# Altium schematic sheet PowerSupply.SchDoc
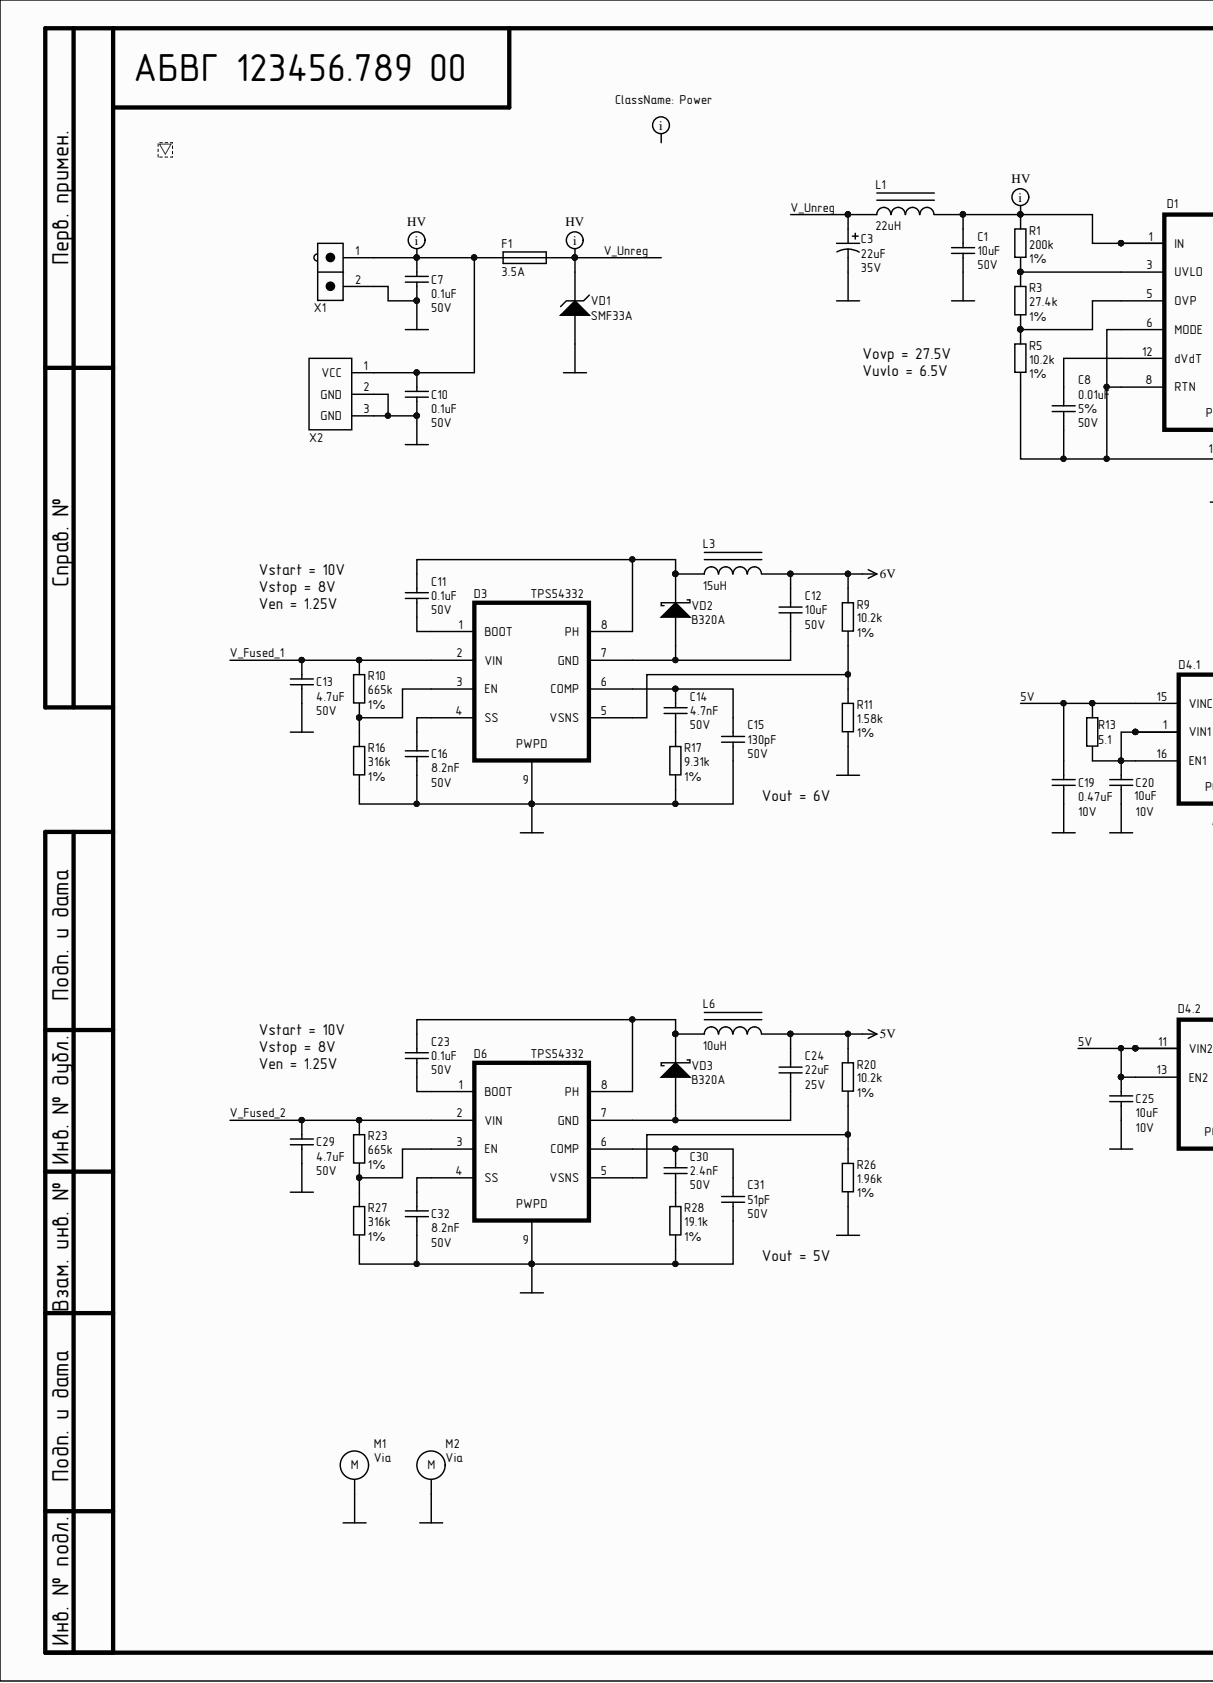
[diagram p0: PowerSupply.SchDoc, left]
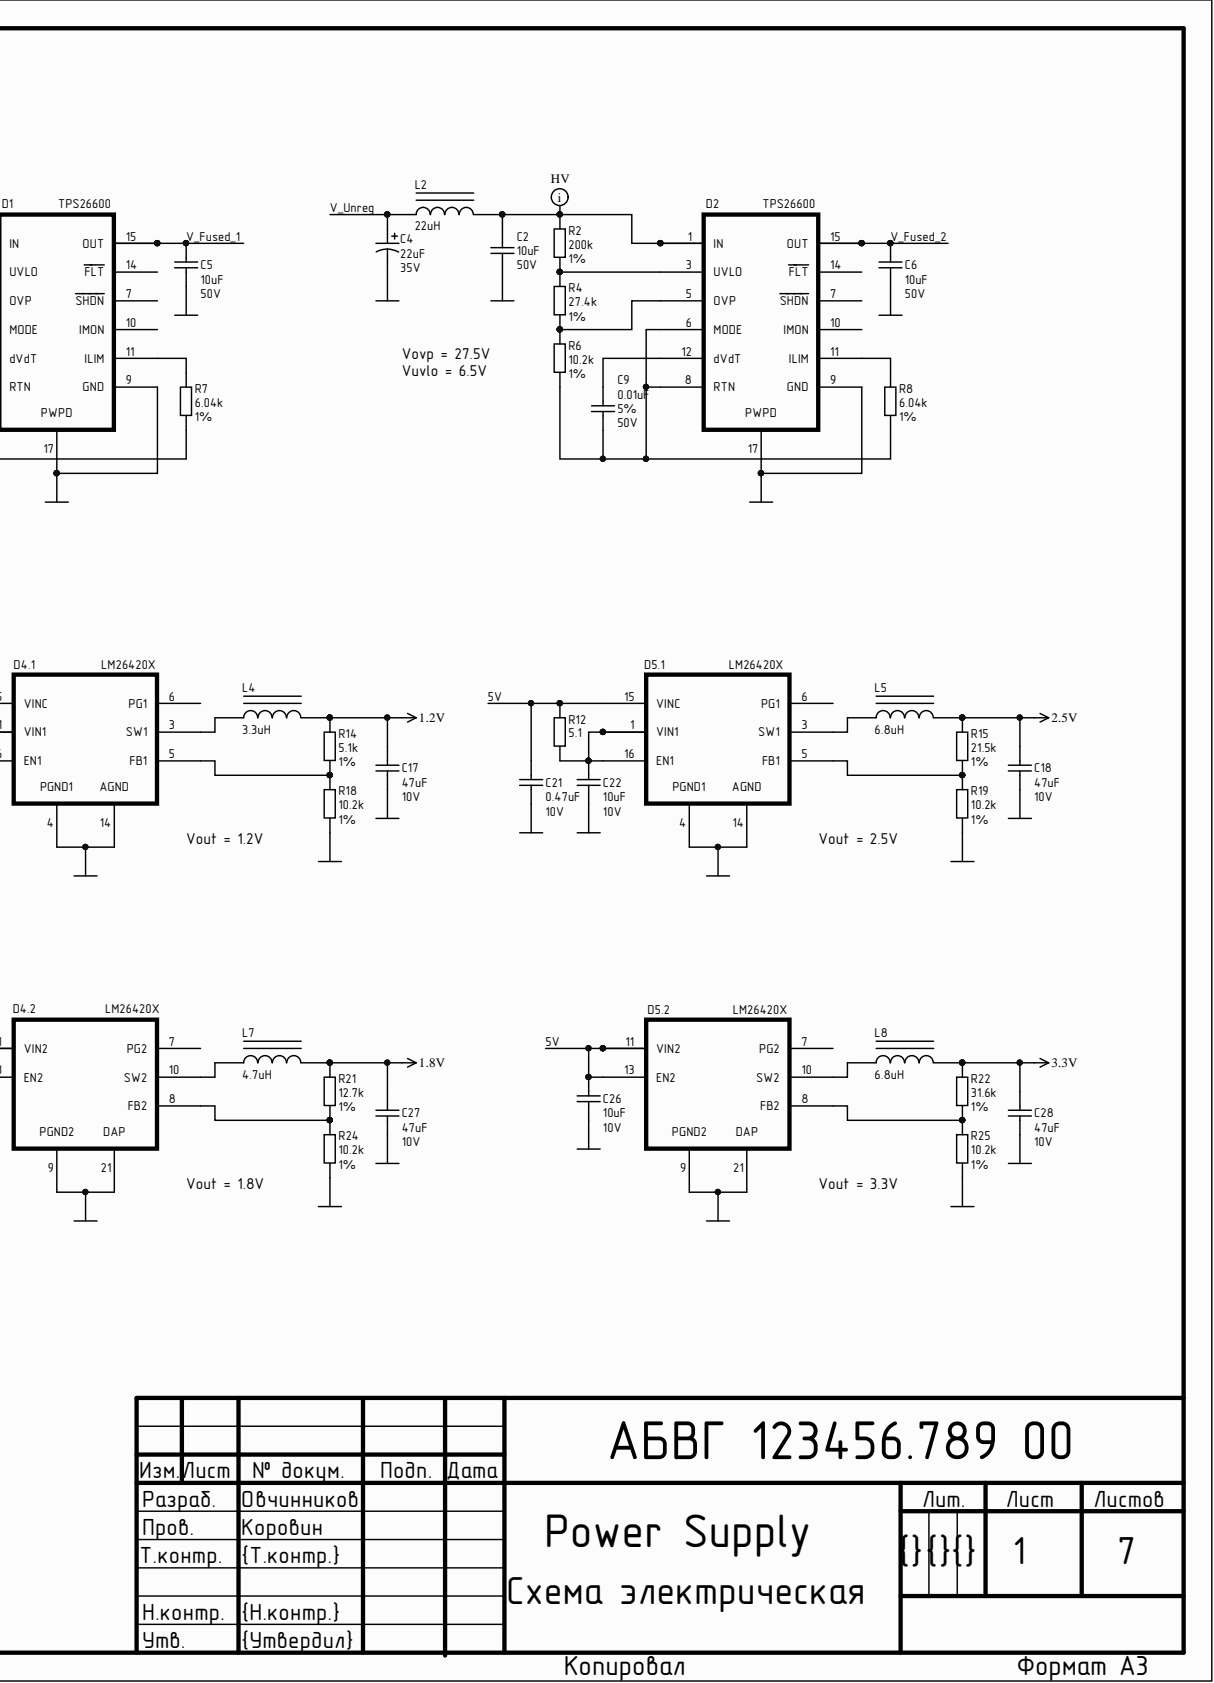
[diagram p1: PowerSupply.SchDoc, right]

## Parts
C1  value 10uF  Voltage: 50V  [C1210]  (hidden comment: CAP uF)
C2  value 10uF  Voltage: 50V  [C1210]  (hidden comment: CAP uF)
C3  value 22uF  Voltage: 35V  [Tantalum_D]  (hidden comment: Cap Polarity)
C4  value 22uF  Voltage: 35V  [Tantalum_D]  (hidden comment: Cap Polarity)
C5  value 10uF  Voltage: 50V  [C1210]  (hidden comment: CAP uF)
C6  value 10uF  Voltage: 50V  [C1210]  (hidden comment: CAP uF)
C7  value 0.1uF  Voltage: 50V  [C0402]  (hidden comment: CAP)
C8  value 0.01uF  Tolerance: 5%  Voltage: 50V  [C0402]  (hidden comment: CAP)
C9  value 0.01uF  Tolerance: 5%  Voltage: 50V  [C0402]  (hidden comment: CAP)
C10  value 0.1uF  Voltage: 50V  [C0402]  (hidden comment: CAP)
C11  value 0.1uF  Voltage: 50V  [C0402]  (hidden comment: CAP)
C12  value 10uF  Voltage: 50V  [C1210]  (hidden comment: CAP uF)
C13  value 4.7uF  Voltage: 50V  [C0805]  (hidden comment: CAP uF)
C14  value 4.7nF  Voltage: 50V  [C0603]  (hidden comment: CAP)
C15  value 130pF  Voltage: 50V  [C0402]  (hidden comment: CAP)
C16  value 8.2nF  Voltage: 50V  [C0402]  (hidden comment: CAP)
C17  value 47uF  Voltage: 10V  [C1210]  (hidden comment: CAP uF)
C18  value 47uF  Voltage: 10V  [C1210]  (hidden comment: CAP uF)
C19  value 0.47uF  Voltage: 10V  [C0603]  (hidden comment: CAP)
C20  value 10uF  Voltage: 10V  [C0805]  (hidden comment: CAP uF)
C21  value 0.47uF  Voltage: 10V  [C0603]  (hidden comment: CAP)
C22  value 10uF  Voltage: 10V  [C0805]  (hidden comment: CAP uF)
C23  value 0.1uF  Voltage: 50V  [C0402]  (hidden comment: CAP)
C24  value 22uF  Voltage: 25V  [C1210]  (hidden comment: CAP uF)
C25  value 10uF  Voltage: 10V  [C0805]  (hidden comment: CAP uF)
C26  value 10uF  Voltage: 10V  [C0805]  (hidden comment: CAP uF)
C27  value 47uF  Voltage: 10V  [C1210]  (hidden comment: CAP uF)
C28  value 47uF  Voltage: 10V  [C1210]  (hidden comment: CAP uF)
C29  value 4.7uF  Voltage: 50V  [C0805]  (hidden comment: CAP uF)
C30  value 2.4nF  Voltage: 50V  [C0603]  (hidden comment: CAP)
C31  value 51pF  Voltage: 50V  [C0402]  (hidden comment: CAP)
C32  value 8.2nF  Voltage: 50V  [C0402]  (hidden comment: CAP)
D1  TPS26600  [R-PDSO-G16]
D2  TPS26600  [R-PDSO-G16]
D3  TPS54332  [R-PDSO-G8]
D4  LM26420X  [HTSSOP-20]  (parts .1,.2)
D5  LM26420X  [HTSSOP-20]  (parts .1,.2)
D6  TPS54332  [R-PDSO-G8]
F1  Current: 3.5A  [Fuse]  (hidden comment: FUSE)
L1  value 22uH  [XAL6060-223]  (hidden comment: Inductor PWR)
L2  value 22uH  [XAL6060-223]  (hidden comment: Inductor PWR)
L3  value 15uH  [XAL5050]  (hidden comment: Inductor PWR)
L4  value 3.3uH  [XAL4030]  (hidden comment: Inductor PWR)
L5  value 6.8uH  [XAL4030]  (hidden comment: Inductor PWR)
L6  value 10uH  [XAL5050]  (hidden comment: Inductor PWR)
L7  value 4.7uH  [XAL4030]  (hidden comment: Inductor PWR)
L8  value 6.8uH  [XAL4030]  (hidden comment: Inductor PWR)
M1  Via  [Mechanical_Via]
M2  Via  [Mechanical_Via]
R1  value 200k  Tolerance: 1%  [R0402]  (hidden comment: RES)
R2  value 200k  Tolerance: 1%  [R0402]  (hidden comment: RES)
R3  value 27.4k  Tolerance: 1%  [R0402]  (hidden comment: RES)
R4  value 27.4k  Tolerance: 1%  [R0402]  (hidden comment: RES)
R5  value 10.2k  Tolerance: 1%  [R0402]  (hidden comment: RES)
R6  value 10.2k  Tolerance: 1%  [R0402]  (hidden comment: RES)
R7  value 6.04k  Tolerance: 1%  [R0402]  (hidden comment: RES)
R8  value 6.04k  Tolerance: 1%  [R0402]  (hidden comment: RES)
R9  value 10.2k  Tolerance: 1%  [R0402]  (hidden comment: RES)
R10  value 665k  Tolerance: 1%  [R0402]  (hidden comment: RES)
R11  value 1.58k  Tolerance: 1%  [R0402]  (hidden comment: RES)
R12  value 5.1  [R0402]  (hidden comment: RES)
R13  value 5.1  [R0402]  (hidden comment: RES)
R14  value 5.1k  Tolerance: 1%  [R0402]  (hidden comment: RES)
R15  value 21.5k  Tolerance: 1%  [R0402]  (hidden comment: RES)
R16  value 316k  Tolerance: 1%  [R0402]  (hidden comment: RES)
R17  value 9.31k  Tolerance: 1%  [R0402]  (hidden comment: RES)
R18  value 10.2k  Tolerance: 1%  [R0402]  (hidden comment: RES)
R19  value 10.2k  Tolerance: 1%  [R0402]  (hidden comment: RES)
R20  value 10.2k  Tolerance: 1%  [R0402]  (hidden comment: RES)
R21  value 12.7k  Tolerance: 1%  [R0402]  (hidden comment: RES)
R22  value 31.6k  Tolerance: 1%  [R0402]  (hidden comment: RES)
R23  value 665k  Tolerance: 1%  [R0402]  (hidden comment: RES)
R24  value 10.2k  Tolerance: 1%  [R0402]  (hidden comment: RES)
R25  value 10.2k  Tolerance: 1%  [R0402]  (hidden comment: RES)
R26  value 1.96k  Tolerance: 1%  [R0402]  (hidden comment: RES)
R27  value 316k  Tolerance: 1%  [R0402]  (hidden comment: RES)
R28  value 19.1k  Tolerance: 1%  [R0402]  (hidden comment: RES)
VD1  SMF33A  [SOD-123F]
VD2  B320A  [Diode_SMA]
VD3  B320A  [Diode_SMA]
X1  miniFit  [2x2 PWR_HDR]  (value not drawn)
X2  Connector DC  [DC_CONNECTOR]  (value not drawn)

## Nets
1.2V: C17.2 L4.2 R14.2
1.8V: C27.2 L7.2 R21.2
2.5V: C18.2 L5.2 R15.2
3.3V: C28.2 L8.2 R22.2
6V: C12.2 L3.2 R9.2
GND: C1.1 C2.1 C3.2 C4.2 C5.1 C6.1 C7.1 C10.1 C13.1 C15.1 C16.1 C17.1 C18.1 C19.2 C20.1 C21.1 C22.1 C25.1 C26.1 C27.1 C28.1 C29.1 C31.1 C32.1 D1.9(GND) D1.17 D2.9(GND) D2.17 D3.9 D4.4 D4.9 D4.14 D4.21 D5.4 D5.9 D5.14 D5.21 D6.9 M1.0 M2.0 R11.1 R16.1 R17.1 R18.1 R19.1 R24.1 R25.1 R26.1 R27.1 R28.1 VD1.2 X1.2 X2.2 X2.3
5V: C19.1 C21.2 C24.2 C25.2 C26.2 D4.11(VIN2) D4.12 D4.13(EN2) D4.15(VINC) D5.11(VIN2) D5.12 D5.13(EN2) D5.15(VINC) L6.2 R12.2 R13.1 R20.2
V_FUSED_1: C5.2 C13.2 D1.15(OUT) D1.16 D3.2(VIN) R10.2
V_FUSED_2: C6.2 C29.2 D2.15(OUT) D2.16 D6.2(VIN) R23.2
V_UNREG: C3.1 C4.1 F1.2 L1.1 L2.1 VD1.1
NetC1_2: C1.2 D1.1(IN) D1.2 L1.2 R1.2
NetC2_2: C2.2 D2.1(IN) D2.2 L2.2 R2.2
NetC7_2: C7.2 C10.2 F1.1 X1.1 X2.1
NetC8_1: C8.1 D1.6(MODE) D1.8(RTN) R5.1 R7.1
NetC8_2: C8.2 D1.12(dVdT)
NetC9_1: C9.1 D2.6(MODE) D2.8(RTN) R6.1 R8.1
NetC9_2: C9.2 D2.12(dVdT)
NetC11_1: C11.1 D3.1(BOOT)
NetC11_2: C11.2 D3.8(PH) L3.1 VD2.1
NetC12_1: C12.1 D3.7(GND) VD2.2
NetC14_1: C14.1 R17.2
NetC14_2: C14.2 C15.2 D3.6(COMP)
NetC16_2: C16.2 D3.4(SS)
NetC20_2: C20.2 D4.1(VIN1) D4.2 D4.16(EN1) R13.2
NetC22_2: C22.2 D5.1(VIN1) D5.2 D5.16(EN1) R12.1
NetC23_1: C23.1 D6.1(BOOT)
NetC23_2: C23.2 D6.8(PH) L6.1 VD3.1
NetC24_1: C24.1 D6.7(GND) VD3.2
NetC30_1: C30.1 R28.2
NetC30_2: C30.2 C31.2 D6.6(COMP)
NetC32_2: C32.2 D6.4(SS)
NetD1_3: D1.3(UVLO) R1.1 R3.2
NetD1_5: D1.5(OVP) R3.1 R5.2
NetD1_11: D1.11(ILIM) R7.2
NetD2_3: D2.3(UVLO) R2.1 R4.2
NetD2_5: D2.5(OVP) R4.1 R6.2
NetD2_11: D2.11(ILIM) R8.2
NetD3_3: D3.3(EN) R10.1 R16.2
NetD3_5: D3.5(VSNS) R9.1 R11.2
NetD4_3: D4.3(SW1) L4.1
NetD4_5: D4.5(FB1) R14.1 R18.2
NetD4_8: D4.8(FB2) R21.1 R24.2
NetD4_10: D4.10(SW2) L7.1
NetD5_3: D5.3(SW1) L5.1
NetD5_5: D5.5(FB1) R15.1 R19.2
NetD5_8: D5.8(FB2) R22.1 R25.2
NetD5_10: D5.10(SW2) L8.1
NetD6_3: D6.3(EN) R23.1 R27.2
NetD6_5: D6.5(VSNS) R20.1 R26.2
N00008:

Not connected: D1 7(SHDN) 10(IMON) 14(FLT); D2 7(SHDN) 10(IMON) 14(FLT); D4 6(PG1) 7(PG2); D5 6(PG1) 7(PG2)

## Library data of the parts
C1 C2 C5 C6 C12 = CAP uF: SMD Capacitor; Manufacturer: Murata; Material: X7R; P/N: GRM32ER71H106KA12L; Tolerance: 10%; Type: Ceramic Capacitor; Component Kind: Standard; Library Name: Kintex_SDR.SchLib
C3 C4 = Cap Polarity: Tantalum Polarity SMD Capacitor; Manufacturer: AVX Corporation; Name: Tantalum Capacitor; P/N: TPSD226K035R0200; Tolerance: 10%; Type: Tantalum Polarity Cap; Component Kind: Standard; Temperature Range: -55…85°C; Library Name: Kintex_SDR.SchLib
C7 C10 C11 C23 = CAP: SMD Capacitor; Manufacturer: Murata; Material: X7R; P/N: GRM155R71H104KE14J; Tolerance: 10%; Type: Ceramic Capacitor; Component Kind: Standard; Library Name: Kintex_SDR.SchLib
C8 C9 = CAP: SMD Capacitor; Manufacturer: Murata; Material: X7R; P/N: GRM155R71H103JA88D; Type: Ceramic Capacitor; Component Kind: Standard; Library Name: Kintex_SDR.SchLib
C13 C29 = CAP uF: SMD Capacitor; Manufacturer: Murata; Material: X5R; P/N: GRM21BR61H475KE51L; Tolerance: 20%; Type: Ceramic Capacitor; Component Kind: Standard; Library Name: Kintex_SDR.SchLib
C14 = CAP: SMD Capacitor; Manufacturer: Murata; Material: NP0; P/N: GRM1885C1H472JA01D; Tolerance: 5%; Type: Ceramic Capacitor; Component Kind: Standard; Library Name: Kintex_SDR.SchLib
C15 = CAP: SMD Capacitor; Manufacturer: Murata; Material: NP0; P/N: GRM1555C1H131JA01D; Tolerance: 5%; Type: Ceramic Capacitor; Component Kind: Standard; Library Name: Kintex_SDR.SchLib
C16 C32 = CAP: SMD Capacitor; Manufacturer: Murata; Material: X7R; P/N: GRM155R71H822KA88D; Tolerance: 10%; Type: Ceramic Capacitor; Component Kind: Standard; Library Name: Kintex_SDR.SchLib
C17 C18 C27 C28 = CAP uF: SMD Capacitor; Manufacturer: Murata; Material: X7R; P/N: GRM32ER71A476KE15L; Tolerance: 10%; Type: Ceramic Capacitor; Component Kind: Standard; Library Name: Kintex_SDR.SchLib
C19 C21 = CAP: SMD Capacitor; Manufacturer: Murata; Material: X7R; P/N: GRM188R71A474KA61D; Tolerance: 10%; Type: Ceramic Capacitor; Component Kind: Standard; Library Name: Kintex_SDR.SchLib
C20 C22 C25 C26 = CAP uF: SMD Capacitor; Manufacturer: Murata; Material: X5R; P/N: GRM21BR71A106KE51L; Tolerance: 10%; Type: Ceramic Capacitor; Component Kind: Standard; Library Name: Kintex_SDR.SchLib
C24 = CAP uF: SMD Capacitor; Manufacturer: Murata; Material: X7R; P/N: GRM32ER71E226KE15L; Tolerance: 10%; Type: Ceramic Capacitor; Component Kind: Standard; Library Name: Kintex_SDR.SchLib
C30 = CAP: SMD Capacitor; Manufacturer: Murata; Material: NP0; P/N: GRM1885C1H242JA01D; Tolerance: 5%; Type: Ceramic Capacitor; Component Kind: Standard; Library Name: Kintex_SDR.SchLib
C31 = CAP: SMD Capacitor; Manufacturer: Murata; Material: NP0; P/N: GRM1555C1H510JA01D; Tolerance: 5%; Type: Ceramic Capacitor; Component Kind: Standard; Library Name: Kintex_SDR.SchLib
D1 D2 = TPS26600: Industrial eFuse; Current: 2A; Voltage: 60V; Component Kind: Standard; Name: TPS26600; Package: 16-Pin SOIC PowerPAD; Temperature Range: −40°C to + 125°C; Type: Industrial eFuse; Library Name: Kintex_SDR.SchLib
D3 D6 = TPS54332: Step-Down DC-DC Converter; Current: 3.5A; Frequency: 1MHz; Input Voltage: 3.5V to 28V; Component Kind: Standard; Name: TPS54332; Package: 8-Pin SOIC PowerPAD; Temperature Range: −40°C to + 150°C; Type: Step-Down DC-DC Converter; Library Name: Kintex_SDR.SchLib
D4 D5 = LM26420X: Synchronous  DC-DC Converter; Current: 2A; Frequency: 2.2MHz; Input Voltage: 3 V to 5.5 V; Component Kind: Standard; Name: LM26420X; Package: HTSSOP 20; Temperature Range: −40°C to + 150°C; Type: Synchronous DC-DC Converter; Library Name: Kintex_SDR.SchLib
F1 = FUSE: Chip SMD Fuse; Name: Fast Fuse; Type: Fast Chip SMD Fuse; Voltage: 125V; Component Kind: Standard; Package: SMD Omni Block; Library Name: Kintex_SDR.SchLib
L1 L2 = Inductor PWR: Magnetic-Core Inductor; Manufacturer: Coilcraft; Current: 5A; Name: XAL6060-223MEB; Tolerance: ±30%; Component Kind: Standard; Temperature Range: -40ºC to 125ºC; Library Name: Kintex_SDR.SchLib
L3 = Inductor PWR: Magnetic-Core Inductor; Manufacturer: Coilcraft; Current: 5A; Name: XAL5050-153MEB; Tolerance: ±30%; Component Kind: Standard; Temperature Range: -40ºC to 125ºC; Library Name: Kintex_SDR.SchLib
L4 = Inductor PWR: Magnetic-Core Inductor; Manufacturer: Coilcraft; Current: 5A; Name: XEL4030-332MEB; Tolerance: ±30%; Component Kind: Standard; Temperature Range: -40ºC to 125ºC; Library Name: Kintex_SDR.SchLib
L5 L8 = Inductor PWR: Magnetic-Core Inductor; Manufacturer: Coilcraft; Current: 5A; Name: XEL4030-682MEB; Tolerance: ±30%; Component Kind: Standard; Temperature Range: -40ºC to 125ºC; Library Name: Kintex_SDR.SchLib
L6 = Inductor PWR: Magnetic-Core Inductor; Manufacturer: Coilcraft; Current: 5A; Name: XAL5050-103MEB; Tolerance: ±30%; Component Kind: Standard; Temperature Range: -40ºC to 125ºC; Library Name: Kintex_SDR.SchLib
L7 = Inductor PWR: Magnetic-Core Inductor; Manufacturer: Coilcraft; Current: 5A; Name: XEL4030-472MEB; Tolerance: ±30%; Component Kind: Standard; Temperature Range: -40ºC to 125ºC; Library Name: Kintex_SDR.SchLib
M1 M2 = Mechanical Via: Component Kind: Standard; Description: Mechanical Via; Library Name: Kintex_SDR.SchLib
R1 R2 = RES: SMD Resistor; Manufacturer: Panasonic Electronic Components; Name: Chip SMD Resistor; P/N: ERJ-2RKF2003X; Power: 0.062 Wt; Component Kind: Standard; Temperature Range: -55…155°C; Library Name: Kintex_SDR.SchLib
R3 R4 = RES: SMD Resistor; Manufacturer: Panasonic Electronic Components; Name: Chip SMD Resistor; P/N: ERJ-2RKF2742X; Power: 0.062 Wt; Component Kind: Standard; Temperature Range: -55…155°C; Library Name: Kintex_SDR.SchLib
R5 R6 R9 R18-R20 R24 R25 = RES: SMD Resistor; Manufacturer: Panasonic Electronic Components; Name: Chip SMD Resistor; P/N: ERJ-2RKF1022X; Power: 0.062 Wt; Component Kind: Standard; Temperature Range: -55…155°C; Library Name: Kintex_SDR.SchLib
R7 R8 = RES: SMD Resistor; Manufacturer: Panasonic Electronic Components; Name: Chip SMD Resistor; P/N: ERJ-2RKF6041X; Power: 0.062 Wt; Component Kind: Standard; Temperature Range: -55…155°C; Library Name: Kintex_SDR.SchLib
R10 R23 = RES: SMD Resistor; Manufacturer: Panasonic Electronic Components; Name: Chip SMD Resistor; P/N: ERJ-2RKF6653X; Power: 0.062 Wt; Component Kind: Standard; Temperature Range: -55…155°C; Library Name: Kintex_SDR.SchLib
R11 = RES: SMD Resistor; Manufacturer: Panasonic Electronic Components; Name: Chip SMD Resistor; P/N: ERJ-2RKF1581X; Power: 0.062 Wt; Component Kind: Standard; Temperature Range: -55…155°C; Library Name: Kintex_SDR.SchLib
R12 R13 = RES: SMD Resistor; Manufacturer: Panasonic Electronic Components; Name: Chip SMD Resistor; P/N: ERJ-2GEJ5R1X; Power: 0.062 Wt; Tolerance: 5%; Component Kind: Standard; Temperature Range: -55…155°C; Library Name: Kintex_SDR.SchLib
R14 = RES: SMD Resistor; Manufacturer: Panasonic Electronic Components; Name: Chip SMD Resistor; P/N: ERJ-2RKF5101X; Power: 0.062 Wt; Component Kind: Standard; Temperature Range: -55…155°C; Library Name: Kintex_SDR.SchLib
R15 = RES: SMD Resistor; Manufacturer: Panasonic Electronic Components; Name: Chip SMD Resistor; P/N: ERJ-2RKF2152X; Power: 0.062 Wt; Component Kind: Standard; Temperature Range: -55…155°C; Library Name: Kintex_SDR.SchLib
R16 R27 = RES: SMD Resistor; Manufacturer: Panasonic Electronic Components; Name: Chip SMD Resistor; P/N: ERJ-2RKF3163X; Power: 0.062 Wt; Component Kind: Standard; Temperature Range: -55…155°C; Library Name: Kintex_SDR.SchLib
R17 = RES: SMD Resistor; Manufacturer: Panasonic Electronic Components; Name: Chip SMD Resistor; P/N: ERJ-2RKF9311X; Power: 0.062 Wt; Component Kind: Standard; Temperature Range: -55…155°C; Library Name: Kintex_SDR.SchLib
R21 = RES: SMD Resistor; Manufacturer: Panasonic Electronic Components; Name: Chip SMD Resistor; P/N: ERJ-2RKF1272X; Power: 0.062 Wt; Component Kind: Standard; Temperature Range: -55…155°C; Library Name: Kintex_SDR.SchLib
R22 = RES: SMD Resistor; Manufacturer: Panasonic Electronic Components; Name: Chip SMD Resistor; P/N: ERJ-2RKF3162X; Power: 0.062 Wt; Component Kind: Standard; Temperature Range: -55…155°C; Library Name: Kintex_SDR.SchLib
R26 = RES: SMD Resistor; Manufacturer: Panasonic Electronic Components; Name: Chip SMD Resistor; P/N: ERJ-2RKF1961X; Power: 0.062 Wt; Component Kind: Standard; Temperature Range: -55…155°C; Library Name: Kintex_SDR.SchLib
R28 = RES: SMD Resistor; Manufacturer: Panasonic Electronic Components; Name: Chip SMD Resistor; P/N: ERJ-2RKF1912X; Power: 0.062 Wt; Component Kind: Standard; Temperature Range: -55…155°C; Library Name: Kintex_SDR.SchLib
VD1 = TVS: TVS Diode; Manufacturer: Littelfuse; Power: 200W; Voltage: 33V; Component Kind: Standard; Name: SMF33A; Package: SOD-123F; Library Name: Kintex_SDR.SchLib
VD2 VD3 = Shottky: Schottky Diode; Component Kind: Standard; Name: B320A; Package: SMC; Library Name: Kintex_SDR.SchLib
X1 = HDR 1x2 miniFit: 1x2 miniFit DC Power Connector; Current: 5A; Name: Mini-Fit Power Connector; Voltage: 12V; Component Kind: Standard; Type: 2x2; Library Name: Kintex_SDR.SchLib
X2 = Connector DC: DC Power Connector 6mm; Current: 5A; Voltage: 12V; Component Kind: Standard; Name: DC Power Connector; Package: Trough Hole; Type: 2.5mm*5.7mm; Library Name: Kintex_SDR.SchLib
